# Altium schematic sheet pca10111.SchDoc
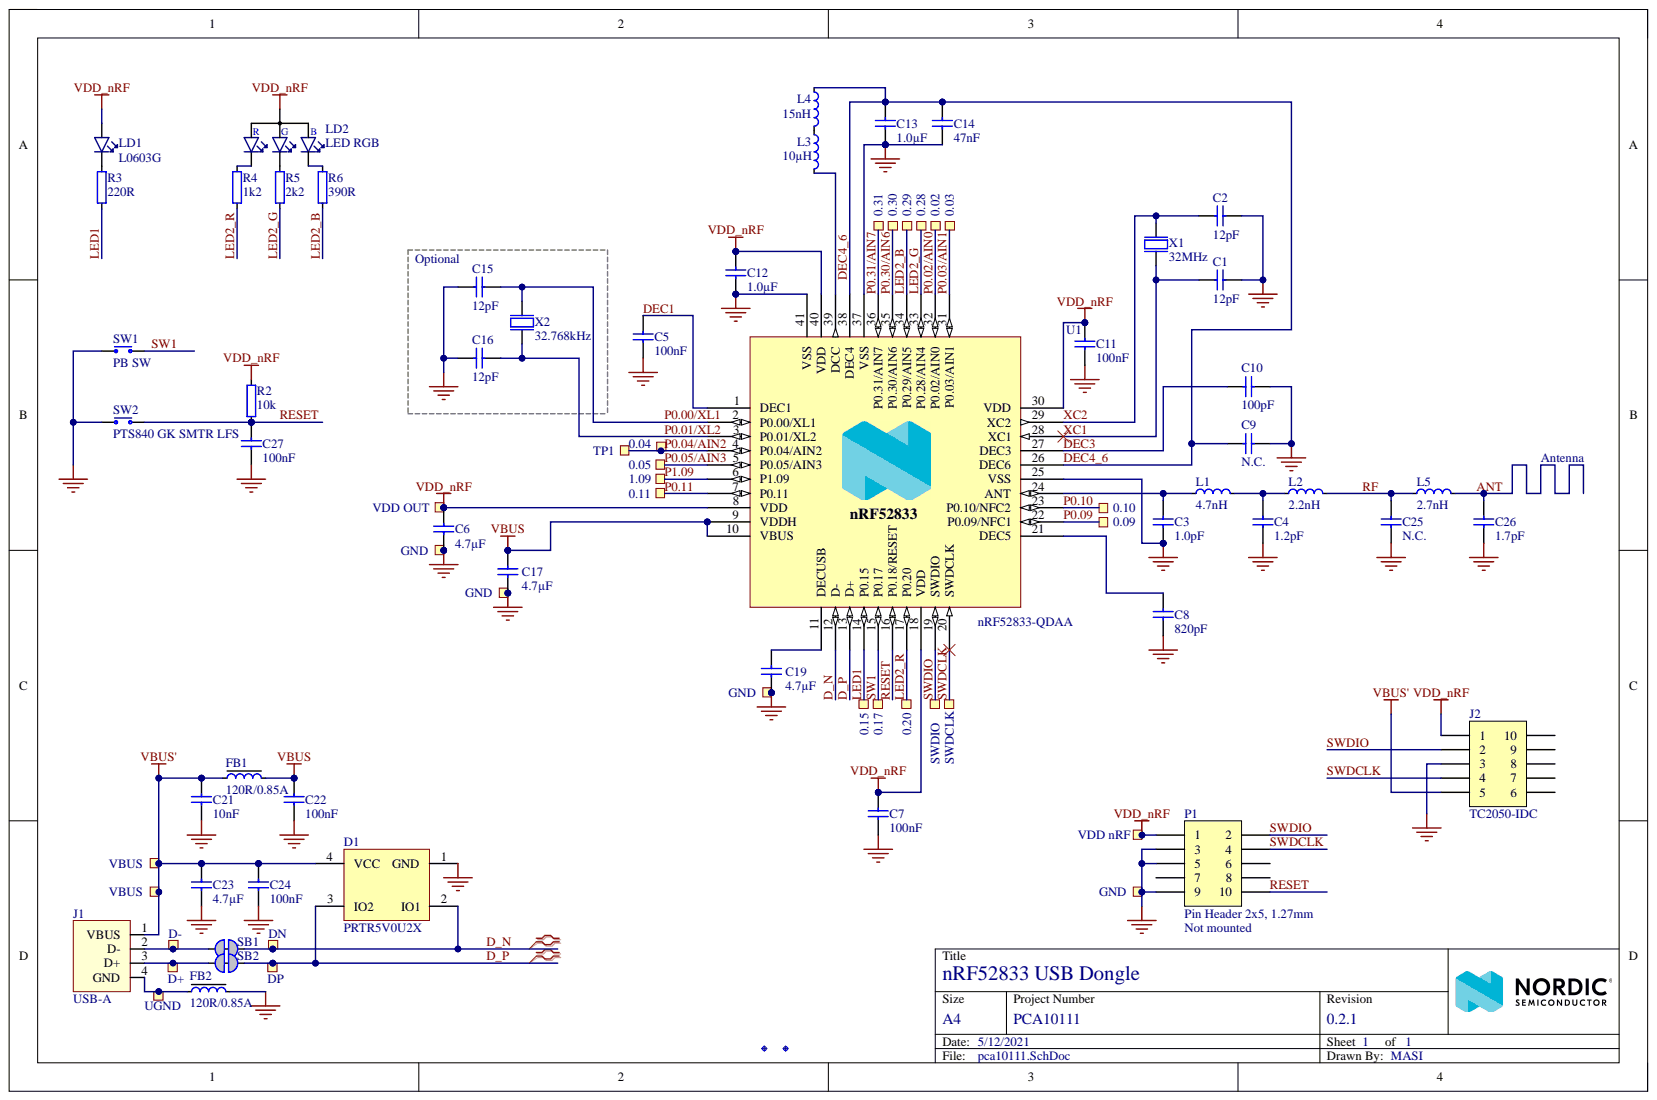
[diagram p0: pca10111.SchDoc]

## Parts
0.02  CH  [Castellated_Hole_0.9mm]  (value not drawn)  (no BOM)
0.03  CH  [Castellated_Hole_0.9mm]  (value not drawn)  (no BOM)
0.04  CH  [Castellated_Hole_0.9mm]  (value not drawn)  (no BOM)
0.05  CH  [Castellated_Hole_0.9mm]  (value not drawn)  (no BOM)
0.09  CH  [Castellated_Hole_0.9mm]  (value not drawn)  (no BOM)
0.10  CH  [Castellated_Hole_0.9mm]  (value not drawn)  (no BOM)
0.11  CH  [Castellated_Hole_0.9mm]  (value not drawn)  (no BOM)
0.15  CH  [Castellated_Hole_0.9mm]  (value not drawn)  (no BOM)
0.17  CH  [Castellated_Hole_0.9mm]  (value not drawn)  (no BOM)
0.20  CH  [Castellated_Hole_0.9mm]  (value not drawn)  (no BOM)
0.28  CH  [Castellated_Hole_0.9mm]  (value not drawn)  (no BOM)
0.29  CH  [Castellated_Hole_0.9mm]  (value not drawn)  (no BOM)
0.30  CH  [Castellated_Hole_0.9mm]  (value not drawn)  (no BOM)
0.31  CH  [Castellated_Hole_0.9mm]  (value not drawn)  (no BOM)
1.09  CH  [Castellated_Hole_0.9mm]  (value not drawn)  (no BOM)
C1  12pF  [CAPC0603X03L_C]
C2  12pF  [CAPC0603X03L_C]
C3  1.0pF  [CAPC0603X03L_C]
C4  1.2pF  [CAPC0603X03L_C]
C5  100nF  [CAPC0603X03L_C]
C6  4.7µF  [CAPC1608X06L]
C7  100nF  [CAPC0603X03L_C]
C8  820pF  [CAPC0603X03L_C]
C9  N.C.  [CAPC0603X03L_C_NC]
C10  100pF  [CAPC0603X03L_C]
C11  100nF  [CAPC0603X03L_C]
C12  1.0µF  [CAPC1005X04L]
C13  1.0µF  [CAPC1005X04L]
C14  47nF  [CAPC0603X03L_C]
C15  12pF  [CAPC0603X03L_C]
C16  12pF  [CAPC0603X03L_C]
C17  4.7µF  [CAPC1608X06L]
C19  4.7µF  [CAPC1608X06L]
C21  10nF  [CAPC0603X03L_C]
C22  100nF  [CAPC0603X03L_C]
C23  4.7µF  [CAPC1005X04L]
C24  100nF  [CAPC0603X03L_C]
C25  N.C.  [CAPC0603X03L_C_NC]
C26  1.7pF  [CAPC0603X03L_C]
C27  100nF  [CAPC0603X03L_C]
D1  PRTR5V0U2X  [SOT190P230X110-4L]
D+  Ø1.0mm,SMD  [TP_SMD_D1.0mm]  (value not drawn)  (no BOM)
D-  Ø1.0mm,SMD  [TP_SMD_D1.0mm]  (value not drawn)  (no BOM)
DN  Ø1.0mm,SMD  [TP_SMD_D1.0mm]  (value not drawn)  (no BOM)
DP  Ø1.0mm,SMD  [TP_SMD_D1.0mm]  (value not drawn)  (no BOM)
FB1  120R/0.85A  [INDC0603X03L_C]
FB2  120R/0.85A  [INDC0603X03L_C]
Fid1  Fiducial mark  [Fiducial_Square]  (value not drawn)
Fid2  Fiducial mark  [Fiducial]  (value not drawn)
GND1  GND  [Castellated_Hole_0.9mm]  (no BOM)
GND2  GND  [Castellated_Hole_0.9mm_S]  (no BOM)
GND3  GND  [Castellated_Hole_0.9mm_S]  (no BOM)
GND4  GND  [TP_SMD_D1.0mm]  (no BOM)
J1  USB-A  [USB-A-PCB]
J2  TC2050-IDC  [TC2050-IDC-NLFP]  (no BOM)
L1  4.7nH  [INDC0603X03L_C]
L2  2.2nH  [INDC0603X03L_C]
L3  10µH  [INDC1608X06L]
L4  15nH  [INDC1005X04L]
L5  2.7nH  [INDC0603X03L_C]
LD1  L0603G  [LED_0603_G]
LD2  LED RGB  [LED_RGB_0603]
P1  Pin Header 2x5, 1.27mm  Assembly: Not mounted  [HDR_2x5-SMD-1.27mm-NC]
R2  10k  [RESC0603X03L_C]
R3  220R  [RESC0603X03L_C]
R4  1k2  [RESC0603X03L_C]
R5  2k2  [RESC0603X03L_C]
R6  390R  [RESC0603X03L_C]
SB1  Solderbridge  [Solderbridge_Shorted]  (value not drawn)
SB2  Solderbridge  [Solderbridge_Shorted]  (value not drawn)
SW1  PB SW  [C&K_PTS810]
SW2  PTS840 GK SMTR LFS  [EVQP7A_J_B_K]
SWDCLK  CH  [Castellated_Hole_0.9mm_D]  (value not drawn)  (no BOM)
SWDIO  CH  [Castellated_Hole_0.9mm_D]  (value not drawn)  (no BOM)
TP1  Ø1.0mm,SMD  [TP_SMD_D1.0mm]  (value not drawn)  (no BOM)
U1  nRF52833-QDAA  [QFN40P500X500X50_HS-41N]
UGND  Ø1.0mm,SMD  [TP_SMD_D1.0mm]  (value not drawn)  (no BOM)
VBUS1  VBUS  [Castellated_Hole_0.9mm]  (no BOM)
VBUS2  VBUS  [TP_SMD_D1.0mm]  (no BOM)
VDD_nRF  Ø1.0mm,SMD  [TP_SMD_D1.0mm]  (value not drawn)  (no BOM)
VDD_OUT  CH  [Castellated_Hole_0.9mm]  (value not drawn)  (no BOM)
X1  32MHz  [BT-XTAL_1612]
X2  32.768kHz  [XTAL_2012]

## Nets
GND: C1.2 C2.2 C3.1 C4.1 C5.2 C6.2 C7.2 C8.2 C9.1 C10.2 C11.2 C12.2 C13.1 C14.2 C15.2 C16.2 C17.2 C19.2 C21.1 C22.1 C23.1 C24.1 C25.2 C26.1 C27.1 D1.1(GND) FB2.2 GND1.1 GND2.1 GND3.1 GND4.1 J2.3 P1.3 P1.5 P1.9 SW1.2 SW2.2 U1.25(VSS) U1.37(VSS) U1.41(VSS) X1.2 X1.4
VBUS: C17.1 C22.2 FB1.2 U1.9(VDDH) U1.10(VBUS)
VBUS': C21.2 C23.2 C24.2 D1.4(VCC) FB1.1 J1.1(VBUS) J2.5 VBUS1.1 VBUS2.1
VDD_nRF: C6.1 C7.1 C11.1 C12.1 J2.1 LD1.2 LD2.4 P1.1 R2.2 U1.8(VDD) U1.18(VDD) U1.30(VDD) U1.40(VDD) VDD_nRF.1 VDD_OUT.1
ANT: C26.2 L5.2
D_N: D1.2(IO1) DN.1 SB1.1 U1.12(D-)
D_P: D1.3(IO2) DP.1 SB2.1 U1.13(D+)
DEC1: C5.1 U1.1(DEC1)
DEC3: C10.1 U1.27(DEC3)
DEC4_6: C9.2 C13.2 C14.1 L4.1 U1.26(DEC6) U1.38(DEC4)
LED1: 0.15.1 R3.2 U1.14(P0.15)
LED2_B: 0.29.1 R6.1 U1.34(P0.29/AIN5)
LED2_G: 0.28.1 R5.1 U1.33(P0.28/AIN4)
LED2_R: 0.20.1 R4.2 U1.17(P0.20)
P0.00/XL1: C15.1 U1.2(P0.00/XL1) X2.1
P0.01/XL2: C16.1 U1.3(P0.01/XL2) X2.2
P0.02/AIN0: 0.02.1 U1.32(P0.02/AIN0)
P0.03/AIN1: 0.03.1 U1.31(P0.03/AIN1)
P0.04/AIN2: 0.04.1 TP1.1 U1.4(P0.04/AIN2)
P0.05/AIN3: 0.05.1 U1.5(P0.05/AIN3)
P0.09: 0.09.1 U1.22(P0.09/NFC1)
P0.10: 0.10.1 U1.23(P0.10/NFC2)
P0.11: 0.11.1 U1.7(P0.11)
P0.30/AIN6: 0.30.1 U1.35(P0.30/AIN6)
P0.31/AIN7: 0.31.1 U1.36(P0.31/AIN7)
P1.09: 1.09.1 U1.6(P1.09)
RESET: C27.2 P1.10 R2.1 SW2.1 U1.16(P0.18/RESET)
RF: C25.1 L2.2 L5.1
SW1: 0.17.1 SW1.1 U1.15(P0.17)
SWDCLK: J2.4 P1.4 SWDCLK.1 U1.20(SWDCLK)
SWDIO: J2.2 P1.2 SWDIO.1 U1.19(SWDIO)
XC1: C1.1 U1.28(XC1) X1.1
XC2: C2.1 U1.29(XC2) X1.3
NetC3_2: C3.2 L1.1 U1.24(ANT)
NetC4_2: C4.2 L1.2 L2.1
NetC8_1: C8.1 U1.21(DEC5)
NetC19_1: C19.1 U1.11(DECUSB)
NetD+_1: D+.1 J1.3(D+) SB2.2
NetD-_1: D-.1 J1.2(D-) SB1.2
NetFB2_1: FB2.1 J1.4(GND) UGND.1
NetL3_1: L3.1 L4.2
NetL3_2: L3.2 U1.39(DCC)
NetLD1_1: LD1.1 R3.1
NetLD2_1: LD2.1 R4.1
NetLD2_2: LD2.2 R5.2
NetLD2_3: LD2.3 R6.2

Not connected: J2 6 7 8 9 10; P1 6 7 8

## Library data of the parts
0.02 0.03 0.04 0.05 0.09-0.11 0.15 0.17 0.20 0.28-0.31 1.09 GND1 VBUS1 VDD_OUT = Castellated Hole 0.9mm: Artikkelnr Noca: N.A.; Database Table Name: Parts$; Pad Count: 1; Component Class: Connectors; ComponentLink1Description: Datasheet; ComponentLink1URL: N.A.; ComponentLink2Description: Supplier link; ComponentLink2URL: N.A.; Description: Castellated Hole 0.9mm; Footprint Doc: N.A.; HelpURL: N.A.; LatestRevisionDate: 2016-08-22; Library Name: AltiumLib.DbLib; Manufacturer: N.A.; Manufacturer Part Number: N.A.; Note: N.A.; Part Number: Castellated Hole 0.9mm; Part number IPS: N.A.; Part number Norautron: N.A.; PartId: 100500565; Published: 2016-08-22; Supplier 1: N.A.; Supplier 2: N.A.; Supplier Part Number 1: N.A.; Supplier Part Number 2: N.A.; Author: rsk
  0.02 0.03 0.04 0.05 0.09-0.11 0.15 0.17 0.20 0.28-0.31 1.09 VDD_OUT: Value: CH
  GND1: Value: GND
  VBUS1: Value: VBUS
C1 C2 C15 C16 = C0201-12p: Capacitor, NP0, ±2%; Part number IPS: 00000007848; Artikkelnr Noca: N.A.; Database Table Name: Parts$; Note: 25V; Pad Count: 2; Component Class: Capacitors; Component Kind: Standard; ComponentLink1Description: Datasheet; ComponentLink1URL: N.A.; ComponentLink2Description: Supplier link; ComponentLink2URL: N.A.; Footprint Doc: 0201; HelpURL: N.A.; LatestRevisionDate: 2017-11-09; Library Name: AltiumLib.DbLib; Manufacturer: N.A.; Manufacturer Part Number: N.A.; Part Number: C0201-12p; Part number Norautron: N.A.; PartId: 100200033; Published: 2014-11-13; Supplier 1: N.A.; Supplier 2: N.A.; Supplier Part Number 1: N.A.; Supplier Part Number 2: N.A.; Value: 12pF; Author: rsk
C3 = C0201-1.0p: Capacitor, NP0, ±0.05pF, 50V; Part number IPS: 00000001247; Artikkelnr Noca: N.A.; Database Table Name: Parts$; Pad Count: 2; Component Class: Capacitors; Component Kind: Standard; ComponentLink1Description: Datasheet; ComponentLink1URL: N.A.; ComponentLink2Description: Supplier link; ComponentLink2URL: N.A.; Footprint Doc: 0201; HelpURL: N.A.; LatestRevisionDate: 2018-10-22; Library Name: AltiumLib.DbLib; Manufacturer: N.A.; Manufacturer Part Number: N.A.; Note: 50V; Part Number: C0201-1.0p; Part number Norautron: N.A.; PartId: 100200014; Published: 2014-11-13; Supplier 1: N.A.; Supplier 2: N.A.; Supplier Part Number 1: N.A.; Supplier Part Number 2: N.A.; Value: 1.0pF; Author: rsk
C4 = C0201-1.2p: Capacitor, C0G, ±0.1pF, 50V; Part number IPS: 00000003190; Artikkelnr Noca: N.A.; Database Table Name: Parts$; Note: Chip Cap 1%; Pad Count: 2; Component Class: Capacitors; Component Kind: Standard; ComponentLink1Description: Datasheet; ComponentLink1URL: N.A.; ComponentLink2Description: Supplier link; ComponentLink2URL: N.A.; Footprint Doc: 0201; HelpURL: N.A.; LatestRevisionDate: 2020-10-13; Library Name: AltiumLib.DbLib; Manufacturer: N.A.; Manufacturer Part Number: N.A.; Part Number: C0201-1.2p; Part number Norautron: N.A.; PartId: 100200015; Published: 2014-11-13; Supplier 1: N.A.; Supplier 2: N.A.; Supplier Part Number 1: N.A.; Supplier Part Number 2: N.A.; Value: 1.2pF; Author: rsk
C5 C7 C11 C22 C24 C27 = C0201-100n: Capacitor, X5R, ±10%; Part number IPS: 00000001248, 00000002505; Artikkelnr Noca: N.A.; Database Table Name: Parts$; Note: 16V; Pad Count: 2; Component Class: Capacitors; Component Kind: Standard; ComponentLink1Description: Datasheet; ComponentLink1URL: N.A.; ComponentLink2Description: Supplier link; ComponentLink2URL: N.A.; Footprint Doc: 0201; HelpURL: N.A.; LatestRevisionDate: 2017-11-09; Library Name: AltiumLib.DbLib; Manufacturer: N.A.; Manufacturer Part Number: N.A.; Part Number: C0201-100n; Part number Norautron: N.A.; PartId: 100200078; Published: 2014-11-13; Supplier 1: N.A.; Supplier 2: N.A.; Supplier Part Number 1: N.A.; Supplier Part Number 2: N.A.; Value: 100nF; Author: rsk
C6 C19 = C0603-4µ7-6.3V-X7R: Capacitor, X7R, ±10%, 6.3V; Manufacturer: Samsung Electro-Mechanics America; Manufacturer Part Number: CL10B475KQ8NQNC; Part number IPS: 00000002541; Part number Norautron: N.A.; Artikkelnr Noca: 20039473; Database Table Name: Parts$; Pad Count: 2; Component Class: Capacitors; Component Kind: Standard; ComponentLink1Description: Datasheet; ComponentLink1URL: N.A.; ComponentLink2Description: Supplier link; ComponentLink2URL: N.A.; Footprint Doc: 0603; HelpURL: http://media.digikey.com/pdf/Data%20Sheets/Samsung%20PDFs/CL10B475KQ8NQNC_Spec.pdf; LatestRevisionDate: 2015-06-10; Library Name: AltiumLib.DbLib; Note: 6.3V; Part Number: C0603-4µ7-6.3V-X7R; PartId: 100200350; Published: 2015-06-10; Supplier 1: Digi-Key; Supplier 2: N.A.; Supplier Part Number 1: 1276-2087-1-ND; Supplier Part Number 2: N.A.; Value: 4.7µF; Author: rsk
C8 = C0201-820p: Capacitor, X7R, ±10%; Part number IPS: 00000001249; Artikkelnr Noca: N.A.; Database Table Name: Parts$; Note: 25V; Pad Count: 2; Component Class: Capacitors; Component Kind: Standard; ComponentLink1Description: Datasheet; ComponentLink1URL: N.A.; ComponentLink2Description: Supplier link; ComponentLink2URL: N.A.; Footprint Doc: 0201; HelpURL: N.A.; LatestRevisionDate: 2017-11-09; Library Name: AltiumLib.DbLib; Manufacturer: N.A.; Manufacturer Part Number: N.A.; Part Number: C0201-820p; Part number Norautron: N.A.; PartId: 100200055; Published: 2014-11-13; Supplier 1: N.A.; Supplier 2: N.A.; Supplier Part Number 1: N.A.; Supplier Part Number 2: N.A.; Value: 820pF; Author: rsk
C9 C25 = C0201-N.C.: Not mounted; Artikkelnr Noca: N.A.; Assembly: Not mounted; Database Table Name: Parts$; Note: Chip Cap; Pad Count: 2; Component Class: Capacitors; Component Kind: Standard; ComponentLink1Description: Datasheet; ComponentLink1URL: N.A.; ComponentLink2Description: Supplier link; ComponentLink2URL: N.A.; Footprint Doc: 0201; HelpURL: N.A.; LatestRevisionDate: 2014-11-13; Library Name: AltiumLib.DbLib; Manufacturer: N.A.; Manufacturer Part Number: N.A.; Part Number: C0201-N.C.; Part number IPS: N.A.; Part number Norautron: N.A.; PartId: 100200001; Published: 2014-11-13; Supplier 1: N.A.; Supplier 2: N.A.; Supplier Part Number 1: N.A.; Supplier Part Number 2: N.A.; Value: N.C.; Author: rsk
C10 = C0201-100p: Capacitor, NP0, ±2%, 25V; Manufacturer: Murata; Manufacturer Part Number: GRM0335C1E101GA01; Part number IPS: 00000007853; Artikkelnr Noca: N.A.; Database Table Name: Parts$; Pad Count: 2; Component Class: Capacitors; Component Kind: Standard; ComponentLink1Description: Datasheet; ComponentLink1URL: N.A.; ComponentLink2Description: Supplier link; ComponentLink2URL: N.A.; Footprint Doc: 0201; HelpURL: N.A.; LatestRevisionDate: 2019-04-16; Library Name: AltiumLib.DbLib; Note: 25V; Part Number: C0201-100p; Part number Norautron: N.A.; PartId: 100200044; Published: 2019-04-16; Supplier 1: N.A.; Supplier 2: N.A.; Supplier Part Number 1: N.A.; Supplier Part Number 2: N.A.; Value: 100pF; Author: rsk
C12 C13 = C0402-1µ0: Capacitor, X5R, ±5%, 6.3V; Manufacturer: N.A.; Part number IPS: 00000007786, 00000003457; Artikkelnr Noca: 20039235; Database Table Name: Parts$; Pad Count: 2; Component Class: Capacitors; Component Kind: Standard; ComponentLink1Description: Datasheet; ComponentLink1URL: N.A.; ComponentLink2Description: Supplier link; ComponentLink2URL: N.A.; Footprint Doc: 0402; HelpURL: N.A.; LatestRevisionDate: 2016-08-22; Library Name: AltiumLib.DbLib; Manufacturer Part Number: N.A.; Note: 6.3V; Part Number: C0402-1µ0; Part number Norautron: N.A.; PartId: 100200190; Published: 2009-05-08; Supplier 1: Digi-Key; Supplier 2: N.A.; Supplier Part Number 1: 1276-1444-1-ND; Supplier Part Number 2: N.A.; Value: 1.0µF; Author: rsk
C14 = C0201-47n: Capacitor, X5R, ±10%; Part number IPS: 00000001250; Artikkelnr Noca: N.A.; Database Table Name: Parts$; Note: 10V; Pad Count: 2; Component Class: Capacitors; Component Kind: Standard; ComponentLink1Description: Datasheet; ComponentLink1URL: N.A.; ComponentLink2Description: Supplier link; ComponentLink2URL: N.A.; Footprint Doc: 0201; HelpURL: N.A.; LatestRevisionDate: 2017-11-09; Library Name: AltiumLib.DbLib; Manufacturer: N.A.; Manufacturer Part Number: N.A.; Part Number: C0201-47n; Part number Norautron: N.A.; PartId: 100200074; Published: 2014-11-13; Supplier 1: N.A.; Supplier 2: N.A.; Supplier Part Number 1: N.A.; Supplier Part Number 2: N.A.; Value: 47nF; Author: rsk
C17 = C0603-4µ7-10V-X7S: Capacitor, X7S, ±10%, 10V; Manufacturer: N.A.; Part number IPS: 00000001779; Artikkelnr Noca: 20030013; Database Table Name: Parts$; Pad Count: 2; Component Class: Capacitors; Component Kind: Standard; ComponentLink1Description: Datasheet; ComponentLink1URL: N.A.; ComponentLink2Description: Supplier link; ComponentLink2URL: N.A.; Footprint Doc: 0603; HelpURL: N.A.; LatestRevisionDate: 2016-08-15; Library Name: AltiumLib.DbLib; Manufacturer Part Number: N.A.; Note: 10V; Part Number: C0603-4µ7-10V-X7S; Part number Norautron: N.A.; PartId: 100200351; Published: 2016-08-15; Supplier 1: Digi-Key; Supplier 2: N.A.; Supplier Part Number 1: 445-14258-1-ND; Supplier Part Number 2: N.A.; Value: 4.7µF; Author: rsk
C21 = C0201-10n: Capacitor, X7R, ±10%; Part number IPS: 00000003480; Artikkelnr Noca: N.A.; Database Table Name: Parts$; Note: 10V; Pad Count: 2; Component Class: Capacitors; Component Kind: Standard; ComponentLink1Description: Datasheet; ComponentLink1URL: N.A.; ComponentLink2Description: Supplier link; ComponentLink2URL: N.A.; Footprint Doc: 0201; HelpURL: N.A.; LatestRevisionDate: 2017-11-09; Library Name: AltiumLib.DbLib; Manufacturer: N.A.; Manufacturer Part Number: N.A.; Part Number: C0201-10n; Part number Norautron: N.A.; PartId: 100200067; Published: 2014-11-13; Supplier 1: N.A.; Supplier 2: N.A.; Supplier Part Number 1: N.A.; Supplier Part Number 2: N.A.; Value: 10nF; Author: rsk
C23 = C0402-4µ7: Capacitor, X5R, ±20%; Manufacturer: N.A.; Part number IPS: 00000001251, 00000007165; Artikkelnr Noca: 20039482; Database Table Name: Parts$; Note: 10V; Pad Count: 2; Component Class: Capacitors; Component Kind: Standard; ComponentLink1Description: Datasheet; ComponentLink1URL: N.A.; ComponentLink2Description: Supplier link; ComponentLink2URL: N.A.; Footprint Doc: 0402; HelpURL: N.A.; LatestRevisionDate: 2017-11-09; Library Name: AltiumLib.DbLib; Manufacturer Part Number: N.A.; Part Number: C0402-4µ7; Part number Norautron: N.A.; PartId: 100200193; Published: 2009-05-08; Supplier 1: Digi-Key; Supplier 2: N.A.; Supplier Part Number 1: 490-13252-1-ND; Supplier Part Number 2: N.A.; Value: 4.7µF; Author: rsk
C26 = C0201-1.7p: Chip Cap 0201; Artikkelnr Noca: N.A.; Database Table Name: Parts$; Note: Chip Cap 1%; Pad Count: 2; Component Class: Capacitors; Component Kind: Standard; ComponentLink1Description: Datasheet; ComponentLink1URL: N.A.; ComponentLink2Description: Supplier link; ComponentLink2URL: N.A.; Footprint Doc: 0201; HelpURL: N.A.; LatestRevisionDate: 2019-12-04; Library Name: AltiumLib.DbLib; Manufacturer: N.A.; Manufacturer Part Number: N.A.; Part Number: C0201-1.7p; Part number IPS: N.A.; Part number Norautron: N.A.; PartId: 100200018; Published: 2014-11-13; Supplier 1: N.A.; Supplier 2: N.A.; Supplier Part Number 1: N.A.; Supplier Part Number 2: N.A.; Value: 1.7pF; Author: rsk
D1 = PRTR5V0U2X: Ultra low capacitance double rail-to-rail ESD protection diode; Manufacturer: NXP Semiconductors; Part number IPS: 00000009951; Artikkelnr Noca: 10069015; Database Table Name: Parts$; Note: N.A.; Pad Count: 4; Component Class: Semiconductors; Component Kind: Standard; ComponentLink1Description: Datasheet; ComponentLink1URL: N.A.; ComponentLink2Description: Supplier link; ComponentLink2URL: N.A.; Footprint Doc: SOT-143B; HelpURL: http://www.farnell.com/datasheets/109718.pdf; LatestRevisionDate: 2017-11-09; Library Name: AltiumLib.DbLib; Manufacturer Part Number: PRTR5V0U2X; Part Number: PRTR5V0U2X; Part number Norautron: N.A.; PartId: 101300082; Published: 2012-06-11; Supplier 1: Digi-Key; Supplier 2: Farnell; Supplier Part Number 1: 568-4140-1-ND; Supplier Part Number 2: 152-4157; Value: PRTR5V0U2X; Author: rsk
D+ D- DN DP GND4 TP1 UGND VBUS2 VDD_nRF = TP_SMD_Ø1.0MM: 1.0mm circular SMD testpad; Manufacturer: Nordic Semiconductor; Artikkelnr Noca: N.A.; Database Table Name: Parts$; Pad Count: 1; Component Class: Connectors; ComponentLink1Description: Datasheet; ComponentLink1URL: N.A.; ComponentLink2Description: Supplier link; ComponentLink2URL: N.A.; Footprint Doc: TP_SMD_Ø1.0mm; HelpURL: N.A.; LatestRevisionDate: 2021-03-05; Library Name: AltiumLib.DbLib; Manufacturer Part Number: N.A.; Note: N.A.; Part Number: TP_SMD_Ø1.0MM; Part number IPS: N.A.; Part number Norautron: N.A.; PartId: 100500475; Published: 2013-06-11; Supplier 1: N.A.; Supplier 2: N.A.; Supplier Part Number 1: N.A.; Supplier Part Number 2: N.A.; Author: rsk
  D+ D- DN DP TP1 UGND VDD_nRF: Value: Ø1.0mm,SMD
  GND4: Value: GND
  VBUS2: Value: VBUS
FB1 FB2 = FB0201-120R@100M-850mA: Ferrite Bead, 120 Ohm @ 100MHz, 850mA, 150 mOhm Max; Manufacturer: Taiyo Yuden; Manufacturer Part Number: BKP0603HS121-T; Part number IPS: 00000001252; Artikkelnr Noca: N.A.; Database Table Name: Parts$; Note: Chip EMIFIL Inductor Type Chip Ferrite Bead; Pad Count: 2; Component Class: Inductors; Component Kind: Standard; ComponentLink1Description: Datasheet; ComponentLink1URL: N.A.; ComponentLink2Description: Supplier link; ComponentLink2URL: N.A.; Footprint Doc: 0201; HelpURL: http://ds.yuden.co.jp/TYCOMPAS/ut/detail.do?productNo=BKP0603HS121-T&fileName=BKP0603HS121-T_SS&mode=specSheetDownload; LatestRevisionDate: 2019-01-29; Library Name: AltiumLib.DbLib; Part Number: FB0201-120R@100M-850mA; Part number Norautron: N.A.; PartId: 100300097; Published: 2016-08-23; Supplier 1: Digi-Key; Supplier 2: N.A.; Supplier Part Number 1: 587-3286-1-ND; Supplier Part Number 2: N.A.; Value: 120R/0.85A; Author: rsk
Fid1 = Fid-Mark-Square: Artikkelnr Noca: N.A.; Database Table Name: Parts$; Pad Count: 1; Value: N.A.; Component Class: Connectors; Component Kind: Standard; ComponentLink1Description: Datasheet; ComponentLink1URL: N.A.; ComponentLink2Description: Supplier link; ComponentLink2URL: N.A.; Description: Fiducial Mark; Footprint Doc: Fiducial; HelpURL: N.A.; LatestRevisionDate: 2017-01-30; Library Name: AltiumLib.DbLib; Manufacturer: N.A.; Manufacturer Part Number: N.A.; Note: N.A.; Part Number: Fid-Mark-Square; Part number IPS: N.A.; Part number Norautron: N.A.; PartId: 100500074; Published: 2009-12-12; Supplier 1: N.A.; Supplier 2: N.A.; Supplier Part Number 1: N.A.; Supplier Part Number 2: N.A.; Author: rsk
Fid2 = Fid-Mark: Artikkelnr Noca: N.A.; Database Table Name: Parts$; Pad Count: 1; Value: N.A.; Component Class: Connectors; Component Kind: Standard; ComponentLink1Description: Datasheet; ComponentLink1URL: N.A.; ComponentLink2Description: Supplier link; ComponentLink2URL: N.A.; Description: Fiducial Mark; Footprint Doc: Fiducial; HelpURL: N.A.; LatestRevisionDate: 2009-12-12; Library Name: AltiumLib.DbLib; Manufacturer: N.A.; Manufacturer Part Number: N.A.; Note: N.A.; Part Number: Fid-Mark; Part number IPS: N.A.; Part number Norautron: N.A.; PartId: 100500073; Published: 2009-12-12; Supplier 1: N.A.; Supplier 2: N.A.; Supplier Part Number 1: N.A.; Supplier Part Number 2: N.A.; Author: rsk
GND2 GND3 = Castellated Hole 0.9mm_S: Castellated Hole 0.9mm; Artikkelnr Noca: N.A.; Database Table Name: Parts$; Pad Count: 1; Component Class: Connectors; ComponentLink1Description: Datasheet; ComponentLink1URL: N.A.; ComponentLink2Description: Supplier link; ComponentLink2URL: N.A.; Footprint Doc: N.A.; HelpURL: N.A.; LatestRevisionDate: 2018-01-24; Library Name: AltiumLib.DbLib; Manufacturer: N.A.; Manufacturer Part Number: N.A.; Note: N.A.; Part Number: Castellated Hole 0.9mm_S; Part number IPS: N.A.; Part number Norautron: N.A.; PartId: 100500566; Published: 2018-01-24; Supplier 1: N.A.; Supplier 2: N.A.; Supplier Part Number 1: N.A.; Supplier Part Number 2: N.A.; Value: GND; Author: rsk
J1 = USB-A-PCB: USB-A PCB footprint; Artikkelnr Noca: N.A.; Database Table Name: Parts$; Pad Count: 4; Component Class: Connectors; Component Kind: Standard; ComponentLink1Description: Datasheet; ComponentLink1URL: N.A.; ComponentLink2Description: Supplier link; ComponentLink2URL: N.A.; Footprint Doc: USB-A-PCB; HelpURL: N.A.; LatestRevisionDate: 2014-06-04; Library Name: AltiumLib.DbLib; Manufacturer: N.A.; Manufacturer Part Number: N.A.; Note: N.A.; Part Number: USB-A-PCB; Part number IPS: N.A.; Part number Norautron: N.A.; PartId: 100500219; Published: 2014-06-04; Supplier 1: N.A.; Supplier 2: N.A.; Supplier Part Number 1: N.A.; Supplier Part Number 2: N.A.; Value: USB-A; Author: rsk
J2 = TC2050-IDC-NLFP: CBL PLUG-OF-NAILS 10-PIN; Manufacturer: Tag-Connect LLC; Artikkelnr Noca: N.A.; Database Table Name: Parts$; Pad Count: 10; Component Class: Connectors; ComponentLink1Description: Datasheet; ComponentLink1URL: N.A.; ComponentLink2Description: Supplier link; ComponentLink2URL: N.A.; Footprint Doc: TC2050-IDC-NLFP; HelpURL: http://www.tag-connect.com/Materials/TC2050-IDC%20Datasheet.pdf; LatestRevisionDate: 2012-11-21; Library Name: AltiumLib.DbLib; Manufacturer Part Number: TC2050-IDC-NLFP; Note: N.A.; Part Number: TC2050-IDC-NLFP; Part number IPS: N.A.; Part number Norautron: N.A.; PartId: 100500463; Published: 2012-11-21; Supplier 1: Digi-Key; Supplier 2: N.A.; Supplier Part Number 1: TC2050-IDC-NL-ND; Supplier Part Number 2: N.A.; Value: TC2050-IDC; Author: rsk
L1 = L0201-4n7: Inductor, 350mA, ±3%; Manufacturer: Murata; Manufacturer Part Number: LQP03TN4N7H02D; Part number IPS: 00000001792; Artikkelnr Noca: N.A.; Database Table Name: Parts$; Pad Count: 2; Component Class: Inductors; Component Kind: Standard; ComponentLink1Description: Datasheet; ComponentLink1URL: N.A.; ComponentLink2Description: Supplier link; ComponentLink2URL: N.A.; Footprint Doc: 0201; HelpURL: N.A.; LatestRevisionDate: 2013-04-10; Library Name: AltiumLib.DbLib; Note: N.A.; Part Number: L0201-4n7; Part number Norautron: N.A.; PartId: 100300024; Published: 2013-04-10; Supplier 1: N.A.; Supplier 2: N.A.; Supplier Part Number 1: N.A.; Supplier Part Number 2: N.A.; Value: 4.7nH; Author: rsk
L2 = L0201-2n2: Chip Inductor; Artikkelnr Noca: N.A.; Database Table Name: Parts$; Note: High frequency chip inductor ±5%; Pad Count: 2; Component Class: Inductors; Component Kind: Standard; ComponentLink1Description: Datasheet; ComponentLink1URL: N.A.; ComponentLink2Description: Supplier link; ComponentLink2URL: N.A.; Footprint Doc: 0201; HelpURL: N.A.; LatestRevisionDate: 2013-04-10; Library Name: AltiumLib.DbLib; Manufacturer: N.A.; Manufacturer Part Number: N.A.; Part Number: L0201-2n2; Part number IPS: N.A.; Part number Norautron: N.A.; PartId: 100300017; Published: 2013-04-10; Supplier 1: N.A.; Supplier 2: N.A.; Supplier Part Number 1: N.A.; Supplier Part Number 2: N.A.; Value: 2.2nH; Author: rsk
L3 = L0603-10µ-TDK: Inductor, 250mA, ±20%, 1.05ohm. -55°C ~ 125°C; Manufacturer: TDK Corporation; Manufacturer Part Number: MLZ1608M100WTD25; Artikkelnr Noca: N.A.; Database Table Name: Parts$; Pad Count: 2; Component Class: Inductors; Component Kind: Standard; ComponentLink1Description: Datasheet; ComponentLink1URL: N.A.; ComponentLink2Description: Supplier link; ComponentLink2URL: N.A.; Footprint Doc: 0603; HelpURL: https://product.tdk.com/info/en/catalog/datasheets/inductor_automotive_decoupling_mlz1608_en.pdf; LatestRevisionDate: 2012-09-19; Library Name: AltiumLib.DbLib; Note: N.A.; Part Number: L0603-10µ-TDK; Part number IPS: N.A.; Part number Norautron: N.A.; PartId: 100300079; Published: 2019-05-06; Supplier 1: Digi-Key; Supplier 2: Mouser; Supplier Part Number 1: 445-175588-1-ND; Supplier Part Number 2: 810-MLZ1608M100WTD25; Value: 10µH; Author: rsk
L4 = L0402-15n: Inductor, 320mA, ±5%; Manufacturer: Taiyo Yuden; Manufacturer Part Number: HK100515NJ-T; Part number IPS: 00000001262; Part number Norautron: N.A.; Artikkelnr Noca: 33029323; Database Table Name: Parts$; Note: High frequency chip inductor ±10%; Pad Count: 2; Component Class: Inductors; Component Kind: Standard; ComponentLink1Description: Datasheet; ComponentLink1URL: N.A.; ComponentLink2Description: Supplier link; ComponentLink2URL: N.A.; Footprint Doc: 0402; HelpURL: http://www.yuden.co.jp/productdata/catalog/en/mlci04_e.pdf; LatestRevisionDate: 2012-09-19; Library Name: AltiumLib.DbLib; Part Number: L0402-15n; PartId: 100300056; Published: 2010-07-12; Supplier 1: Digi-Key; Supplier 2: Farnell; Supplier Part Number 1: 587-1521-1-ND; Supplier Part Number 2: 658-1328; Value: 15nH; Author: rsk
L5 = L0201-2n7: Chip Inductor; Artikkelnr Noca: N.A.; Database Table Name: Parts$; Note: High frequency chip inductor ±5%; Pad Count: 2; Component Class: Inductors; Component Kind: Standard; ComponentLink1Description: Datasheet; ComponentLink1URL: N.A.; ComponentLink2Description: Supplier link; ComponentLink2URL: N.A.; Footprint Doc: 0201; HelpURL: N.A.; LatestRevisionDate: 2013-04-10; Library Name: AltiumLib.DbLib; Manufacturer: N.A.; Manufacturer Part Number: N.A.; Part Number: L0201-2n7; Part number IPS: N.A.; Part number Norautron: N.A.; PartId: 100300019; Published: 2013-04-10; Supplier 1: N.A.; Supplier 2: N.A.; Supplier Part Number 1: N.A.; Supplier Part Number 2: N.A.; Value: 2.7nH; Author: rsk
LD1 = VS 25C7M5: LED, Green, 0603, 573nm, Vf=2.0V, 24mcd, - 40 to +85°C; Manufacturer: Victory Electronics; Part number IPS: 00000001274, 00000009961; Artikkelnr Noca: 13019193; Database Table Name: Parts$; Note: N.A.; Pad Count: 2; Component Class: Optoelectronics; Component Kind: Standard; ComponentLink1Description: Datasheet; ComponentLink1URL: N.A.; ComponentLink2Description: Supplier link; ComponentLink2URL: N.A.; Footprint Doc: 0603; HelpURL: \\hizz\pro\app9717\Datasheet\optoelectronics\Victory Electronics\VS 25C7M5.pdf; LatestRevisionDate: 2020-10-23; Library Name: AltiumLib.DbLib; Manufacturer Part Number: VS 25C7M5; Part Number: VS 25C7M5; Part number Norautron: N.A.; PartId: 101400097; Published: 2017-08-21; Supplier 1: EC Partner; Supplier 2: N.A.; Supplier Part Number 1: N.A.; Supplier Part Number 2: N.A.; Value: L0603G; Author: rsk
LD2 = APHF1608LSEEQBDZGKC: RGB LED 1.60 mm x 0.80 mm SMD; Manufacturer: Kingbright Company LLC; Part number IPS: 00000001254; Artikkelnr Noca: N.A.; Database Table Name: Parts$; Pad Count: 4; Component Class: Optoelectronics; Component Kind: Standard; ComponentLink1Description: Datasheet; ComponentLink1URL: N.A.; ComponentLink2Description: Supplier link; ComponentLink2URL: N.A.; Footprint Doc: LED_RGB_0603; HelpURL: http://www.kingbrightusa.com/images/catalog/SPEC/APHF1608LSEEQBDZGKC.pdf; LatestRevisionDate: 2017-11-09; Library Name: AltiumLib.DbLib; Manufacturer Part Number: APHF1608LSEEQBDZGKC; Note: N.A.; Part Number: APHF1608LSEEQBDZGKC; Part number Norautron: N.A.; PartId: 101400123; Published: 2017-09-18; Supplier 1: Digi-Key; Supplier 2: N.A.; Supplier Part Number 1: 754-2143-1-ND; Supplier Part Number 2: N.A.; Value: LED RGB; Author: rsk
P1 = Pin Header 2x5 SMD, 1.27mm, KS, N.C.: Pin Header 2x5, 1.27mm (50mil), SMD, Keying Shroud; Manufacturer: W-CON; Manufacturer Part Number: 3132-10MS0BK00R1; Artikkelnr Noca: N.A.; Database Table Name: Parts$; Pad Count: 10; Component Class: Connectors; Component Kind: Standard; ComponentLink1Description: Datasheet; ComponentLink1URL: N.A.; ComponentLink2Description: Supplier link; ComponentLink2URL: N.A.; Footprint Doc: HDR_2x5-SMD-1.27mm; HelpURL: \\hizz\pro\app9717\Datasheet\connectors\W-CON\3132-XXMXXBK00XX.pdf; LatestRevisionDate: 2017-09-07; Library Name: AltiumLib.DbLib; Note: N.A.; Part Number: Pin Header 2x5 SMD, 1.27mm, KS, N.C.; Part number IPS: N.A.; Part number Norautron: N.A.; PartId: 100500262; Published: 2017-09-07; Supplier 1: Cabcon; Supplier 2: Samtec; Supplier Part Number 1: 3132-10MS0BK00R1; Supplier Part Number 2: FTSH-105-01-F-DV-K; Value: Pin Header 2x5, 1.27mm; Author: rsk
R2 = R0201-10k: Resistor, ±1%, 0.05W; Part number IPS: 00000001255; Artikkelnr Noca: N.A.; Database Table Name: Parts$; Note: Chip Res 1%; Pad Count: 2; Component Class: Resistors; Component Kind: Standard; ComponentLink1Description: Datasheet; ComponentLink1URL: N.A.; ComponentLink2Description: Supplier link; ComponentLink2URL: N.A.; Footprint Doc: 0201; HelpURL: N.A.; LatestRevisionDate: 2014-11-13; Library Name: AltiumLib.DbLib; Manufacturer: N.A.; Manufacturer Part Number: N.A.; Part Number: R0201-10k; Part number Norautron: N.A.; PartId: 100100065; Published: 2014-11-13; Supplier 1: N.A.; Supplier 2: N.A.; Supplier Part Number 1: N.A.; Supplier Part Number 2: N.A.; Value: 10k; Author: rsk
R3 = R0201-220: Resistor, ±1%, 0.05W; Part number IPS: 00000000863; Artikkelnr Noca: N.A.; Database Table Name: Parts$; Note: Chip Res 1%; Pad Count: 2; Component Class: Resistors; Component Kind: Standard; ComponentLink1Description: Datasheet; ComponentLink1URL: N.A.; ComponentLink2Description: Supplier link; ComponentLink2URL: N.A.; Footprint Doc: 0201; HelpURL: N.A.; LatestRevisionDate: 2014-11-13; Library Name: AltiumLib.DbLib; Manufacturer: N.A.; Manufacturer Part Number: N.A.; Part Number: R0201-220; Part number Norautron: N.A.; PartId: 100100032; Published: 2014-11-13; Supplier 1: N.A.; Supplier 2: N.A.; Supplier Part Number 1: N.A.; Supplier Part Number 2: N.A.; Value: 220R; Author: rsk
R4 = R0201-1k2: Resistor, ±1%, 0.05W; Part number IPS: 00000001256; Artikkelnr Noca: N.A.; Database Table Name: Parts$; Note: Chip Res 1%; Pad Count: 2; Component Class: Resistors; Component Kind: Standard; ComponentLink1Description: Datasheet; ComponentLink1URL: N.A.; ComponentLink2Description: Supplier link; ComponentLink2URL: N.A.; Footprint Doc: 0201; HelpURL: N.A.; LatestRevisionDate: 2014-11-13; Library Name: AltiumLib.DbLib; Manufacturer: N.A.; Manufacturer Part Number: N.A.; Part Number: R0201-1k2; Part number Norautron: N.A.; PartId: 100100045; Published: 2014-11-13; Supplier 1: N.A.; Supplier 2: N.A.; Supplier Part Number 1: N.A.; Supplier Part Number 2: N.A.; Value: 1k2; Author: rsk
R5 = R0201-2k2: Resistor, ±1%, 0.05W; Part number IPS: 00000001257; Artikkelnr Noca: N.A.; Database Table Name: Parts$; Note: Chip Res 1%; Pad Count: 2; Component Class: Resistors; Component Kind: Standard; ComponentLink1Description: Datasheet; ComponentLink1URL: N.A.; ComponentLink2Description: Supplier link; ComponentLink2URL: N.A.; Footprint Doc: 0201; HelpURL: N.A.; LatestRevisionDate: 2014-11-13; Library Name: AltiumLib.DbLib; Manufacturer: N.A.; Manufacturer Part Number: N.A.; Part Number: R0201-2k2; Part number Norautron: N.A.; PartId: 100100052; Published: 2014-11-13; Supplier 1: N.A.; Supplier 2: N.A.; Supplier Part Number 1: N.A.; Supplier Part Number 2: N.A.; Value: 2k2; Author: rsk
R6 = R0201-390: Resistor, ±1%, 0.05W; Part number IPS: 00000001258; Artikkelnr Noca: N.A.; Database Table Name: Parts$; Note: Chip Res 1%; Pad Count: 2; Component Class: Resistors; Component Kind: Standard; ComponentLink1Description: Datasheet; ComponentLink1URL: N.A.; ComponentLink2Description: Supplier link; ComponentLink2URL: N.A.; Footprint Doc: 0201; HelpURL: N.A.; LatestRevisionDate: 2014-11-13; Library Name: AltiumLib.DbLib; Manufacturer: N.A.; Manufacturer Part Number: N.A.; Part Number: R0201-390; Part number Norautron: N.A.; PartId: 100100035; Published: 2014-11-13; Supplier 1: N.A.; Supplier 2: N.A.; Supplier Part Number 1: N.A.; Supplier Part Number 2: N.A.; Value: 390R; Author: rsk
SB1 SB2 = Solderbridge Shorted: Shorted Solderbridge; Artikkelnr Noca: N.A.; Database Table Name: Parts$; Pad Count: 2; Component Class: Connectors; Component Kind: Net Tie; ComponentLink1Description: Datasheet; ComponentLink1URL: N.A.; ComponentLink2Description: Supplier link; ComponentLink2URL: N.A.; Footprint Doc: Solderbridge_Shorted; HelpURL: N.A.; LatestRevisionDate: 2012-08-07; Library Name: AltiumLib.DbLib; Manufacturer: N.A.; Manufacturer Part Number: N.A.; Note: N.A.; Part Number: Solderbridge Shorted; Part number IPS: N.A.; Part number Norautron: N.A.; PartId: 100500459; Published: 2012-08-07; Supplier 1: N.A.; Supplier 2: N.A.; Supplier Part Number 1: N.A.; Supplier Part Number 2: N.A.; Value: Solderbridge; Author: rsk
SW1 = PTS810 SJK 250 SMTR LFS: Tactile Switch, SPNO, SMD, 260gf, 4.2x3.2x2.5mm; Manufacturer: C&K; Part number IPS: 00000001259; Artikkelnr Noca: N.A.; Database Table Name: Parts$; Pad Count: 4; Component Class: Switches; Component Kind: Standard; ComponentLink1Description: Datasheet; ComponentLink1URL: N.A.; ComponentLink2Description: Supplier link; ComponentLink2URL: N.A.; Footprint Doc: PTS810; HelpURL: http://www.ckswitches.com/media/1476/pts810.pdf; LatestRevisionDate: 2017-11-09; Library Name: AltiumLib.DbLib; Manufacturer Part Number: PTS810 SJK 250 SMTR LFS; Note: N.A.; Part Number: PTS810 SJK 250 SMTR LFS; Part number Norautron: N.A.; PartId: 101500104; Published: 2017-09-18; Supplier 1: Digi-Key; Supplier 2: N.A.; Supplier Part Number 1: CKN10503TR-ND; Supplier Part Number 2: N.A.; Value: PB SW; Author: rsk
SW2 = PTS840 GK SMTR LFS: Microminiature SMT Switch, Side Actuated; Manufacturer: C&K; Part number IPS: 00000001260; Part number Norautron: N.A.; Artikkelnr Noca: 50019089; Database Table Name: Parts$; Note: Tactile Switch, SPST, SMD, 220gf; Pad Count: 4; Component Class: Switches; Component Kind: Standard; ComponentLink1Description: Datasheet; ComponentLink1URL: N.A.; ComponentLink2Description: Supplier link; ComponentLink2URL: N.A.; Footprint Doc: PTS840 Gx; HelpURL: http://www.ck-components.com/80185/pts840_11june13.pdf/; LatestRevisionDate: 2017-11-09; Library Name: AltiumLib.DbLib; Manufacturer Part Number: PTS840 GK SMTR LFS; Part Number: PTS840 GK SMTR LFS; PartId: 101500010; Published: 2014-08-12; Supplier 1: Digi-Key; Supplier 2: Mouser; Supplier Part Number 1: CKN10508CT-ND; Supplier Part Number 2: 611-PTS840GKSMTR; Value: PTS840 GK SMTR LFS; Author: rsk
SWDCLK SWDIO = Castellated Hole 0.9mm_D: Castellated Hole 0.9mm; Artikkelnr Noca: N.A.; Database Table Name: Parts$; Pad Count: 1; Component Class: Connectors; ComponentLink1Description: Datasheet; ComponentLink1URL: N.A.; ComponentLink2Description: Supplier link; ComponentLink2URL: N.A.; Footprint Doc: N.A.; HelpURL: N.A.; LatestRevisionDate: 2018-01-24; Library Name: AltiumLib.DbLib; Manufacturer: N.A.; Manufacturer Part Number: N.A.; Note: N.A.; Part Number: Castellated Hole 0.9mm_D; Part number IPS: N.A.; Part number Norautron: N.A.; PartId: 100500567; Published: 2018-01-24; Supplier 1: N.A.; Supplier 2: N.A.; Supplier Part Number 1: N.A.; Supplier Part Number 2: N.A.; Value: CH; Author: rsk
U1 = nRF52833-QDAA: Multi-protocol Bluetooth Low Energy, IEEE 802.15.4, ANT and 2.4GHz proprietary system-on-chip; Manufacturer: Nordic Semiconductor; Artikkelnr Noca: N.A.; Database Table Name: Parts$; Pad Count: 40; Component Class: nRF_Devices; Component Kind: Standard; ComponentLink1Description: Datasheet; ComponentLink1URL: N.A.; ComponentLink2Description: Supplier link; ComponentLink2URL: N.A.; Footprint Doc: QFN-40; HelpURL: N.A.; LatestRevisionDate: 2018-12-03; Library Name: AltiumLib.DbLib; Manufacturer Part Number: nRF52833-QDAA; Note: N.A.; Part Number: nRF52833-QDAA; Part number IPS: N.A.; Part number Norautron: N.A.; PartId: 102000125; Published: 2018-12-03; Supplier 1: Nordic Semiconductor; Supplier 2: N.A.; Supplier Part Number 1: nRF52833-QDAA; Supplier Part Number 2: N.A.; Value: nRF52833-QDAA; Author: rsk
X1 = XTAL_SMD1612_32MHz_8pF_General: XTAL SMD 1612, 32MHz, Cl=8pF, Total Tol: ±40ppm; Artikkelnr Noca: N.A.; Database Table Name: Parts$; Note: XTAL SMD 32.000MHz; Pad Count: 4; Component Class: Oscillators; Component Kind: Standard; ComponentLink1Description: Datasheet; ComponentLink1URL: N.A.; ComponentLink2Description: Supplier link; ComponentLink2URL: N.A.; Footprint Doc: XTAL_1612; HelpURL: N.A.; LatestRevisionDate: 2016-08-19; Library Name: AltiumLib.DbLib; Manufacturer: N.A.; Manufacturer Part Number: N.A.; Part Number: XTAL_SMD1612_32MHz_8pF_General; Part number IPS: N.A.; Part number Norautron: N.A.; PartId: 101600025; Published: 2016-08-19; Supplier 1: N.A.; Supplier 2: N.A.; Supplier Part Number 1: N.A.; Supplier Part Number 2: N.A.; Value: 32MHz; Author: rsk
X2 = XTAL_SMD2012_32.768kHz_9pF_General: XTAL SMD 2012, 32.768kHz, Cl=9pF, Total Tol: ±50ppm; Artikkelnr Noca: N.A.; Database Table Name: Parts$; Note: XTAL SMD 32.768kHz; Pad Count: 2; Component Class: Oscillators; Component Kind: Standard; ComponentLink1Description: Datasheet; ComponentLink1URL: N.A.; ComponentLink2Description: Supplier link; ComponentLink2URL: N.A.; Footprint Doc: XTAL_2012; HelpURL: N.A.; LatestRevisionDate: 2017-01-11; Library Name: AltiumLib.DbLib; Manufacturer: N.A.; Manufacturer Part Number: N.A.; Part Number: XTAL_SMD2012_32.768kHz_9pF_General; Part number IPS: N.A.; Part number Norautron: N.A.; PartId: 101600011; Published: 2016-08-19; Supplier 1: N.A.; Supplier 2: N.A.; Supplier Part Number 1: N.A.; Supplier Part Number 2: N.A.; Value: 32.768kHz; Author: rsk
